# Altium schematic sheet STM32.SchDoc(STM32)
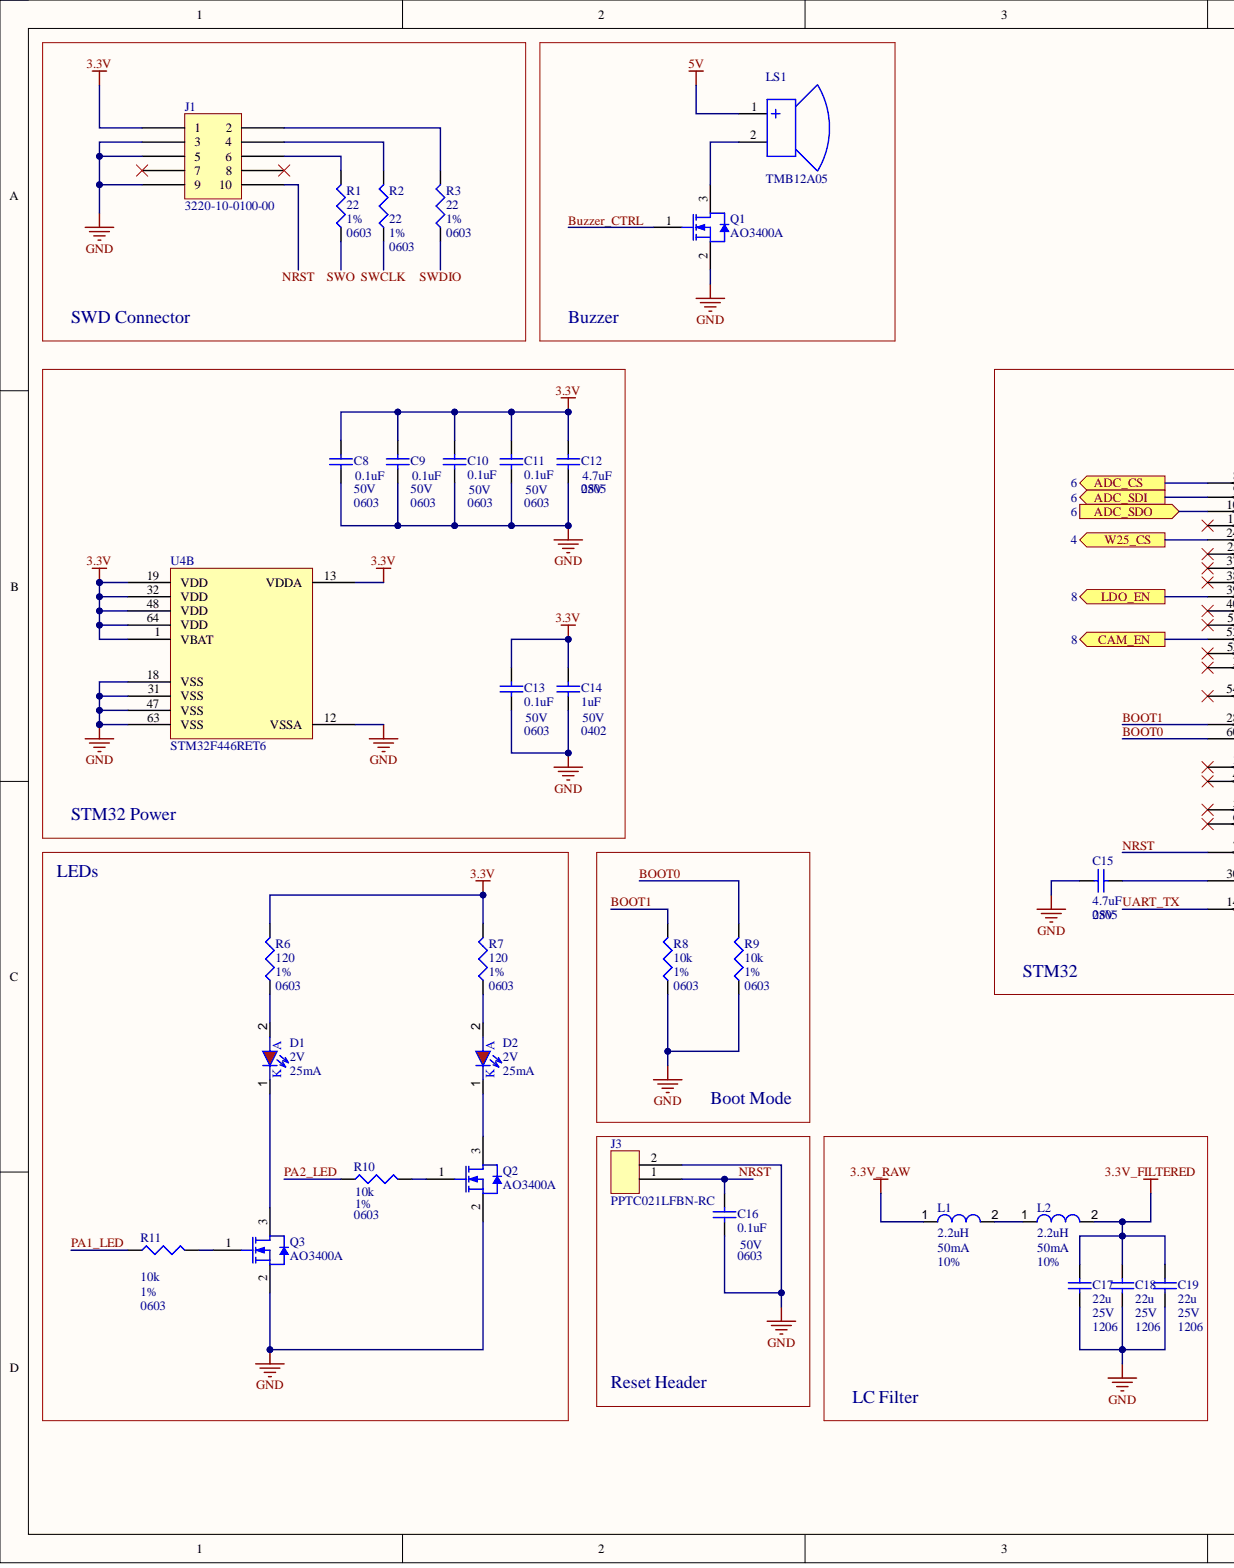
[diagram p0: STM32.SchDoc, left]
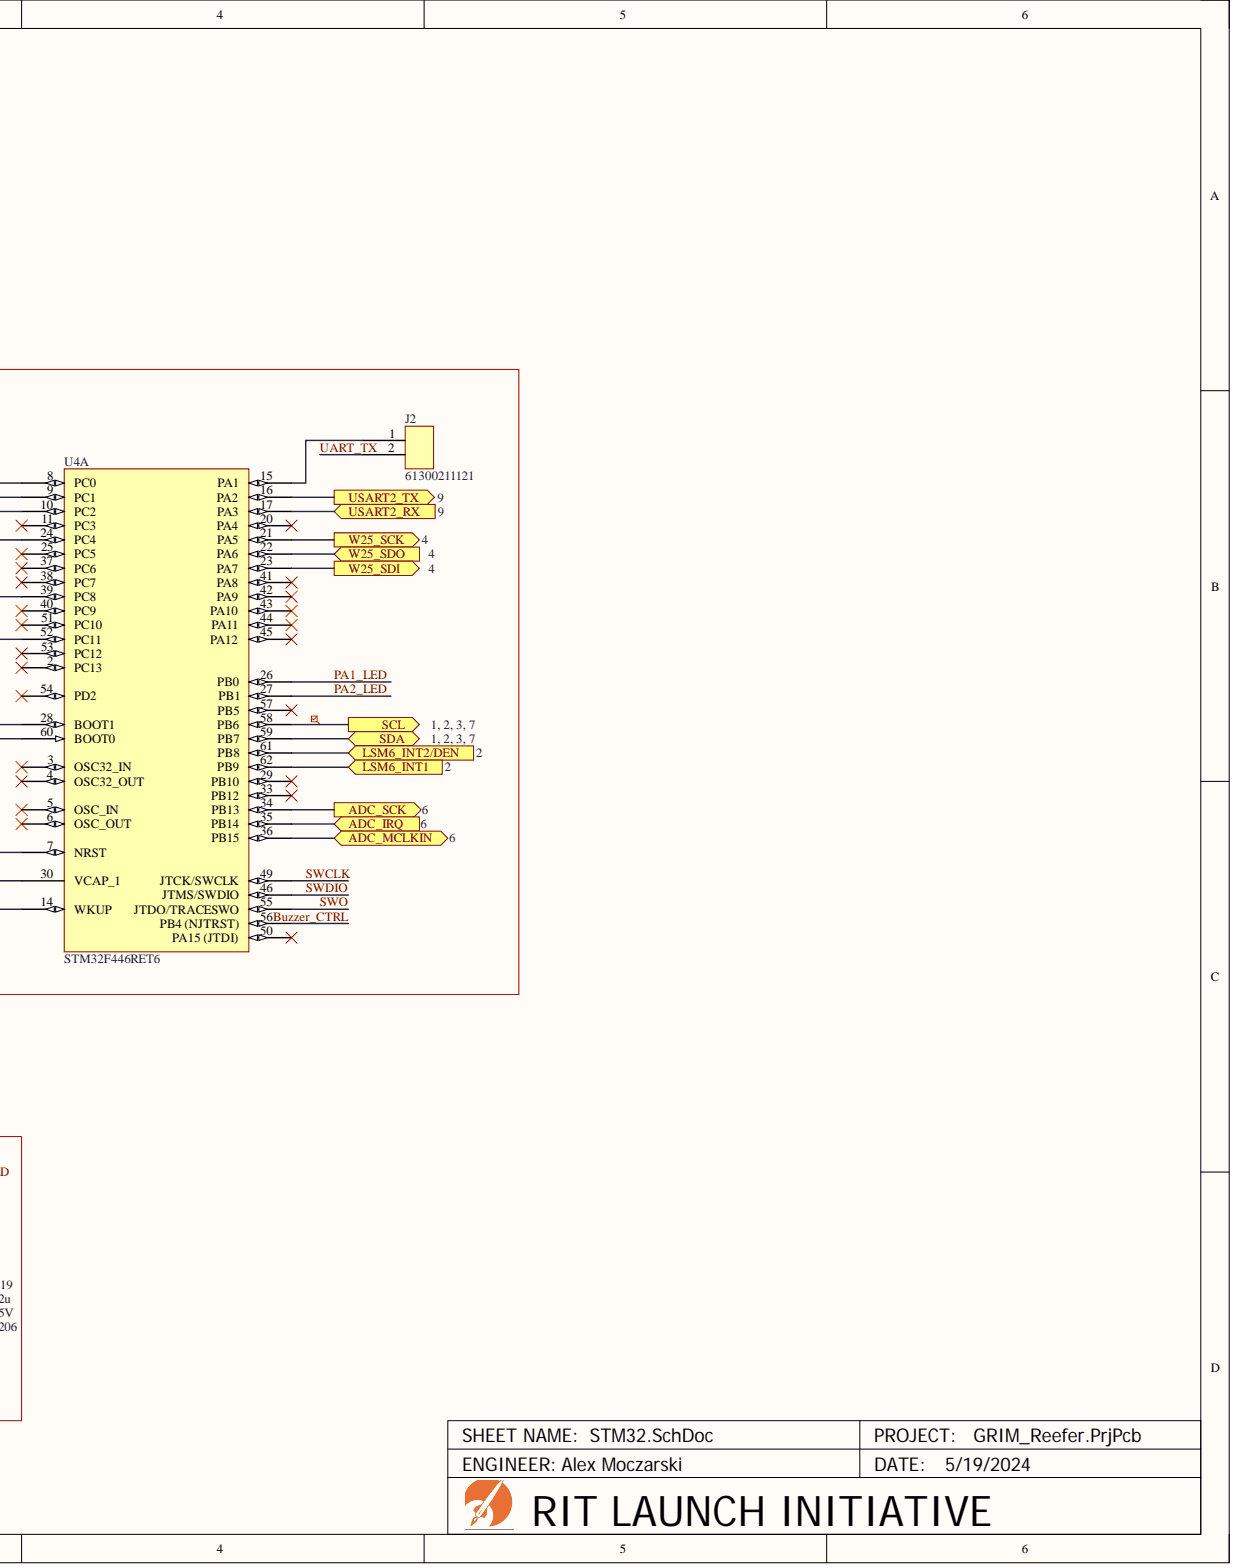
[diagram p1: STM32.SchDoc, right]

## Parts
C8  Capacitance: 0.1uF  Case Code (Imperial): 0603  Voltage: 50V  (hidden comment: CC0603KRX7R9BB104)
C9  Capacitance: 0.1uF  Case Code (Imperial): 0603  Voltage: 50V  (hidden comment: CC0603KRX7R9BB104)
C10  Capacitance: 0.1uF  Case Code (Imperial): 0603  Voltage: 50V  (hidden comment: CC0603KRX7R9BB104)
C11  Capacitance: 0.1uF  Case Code (Imperial): 0603  Voltage: 50V  (hidden comment: CC0603KRX7R9BB104)
C12  Capacitance: 4.7uF  Case/Package: 0805  Voltage: 25V  (hidden comment: CL21A475KAQNNNE)
C13  Capacitance: 0.1uF  Case Code (Imperial): 0603  Voltage: 50V  (hidden comment: CC0603KRX7R9BB104)
C14  Capacitance: 1uF  Case/Package: 0402  Voltage: 50V  (hidden comment: CL05A105KA5NQNC)
C15  Capacitance: 4.7uF  Case/Package: 0805  Voltage: 25V  (hidden comment: CL21A475KAQNNNE)
C16  Capacitance: 0.1uF  Case Code (Imperial): 0603  Voltage: 50V  (hidden comment: CC0603KRX7R9BB104)
C17  Capacitance: 22u  Case Code (Imperial): 1206  Tolerance: 10%  Voltage: 25V  (hidden comment: CL31A226KAHNNNE)
C18  Capacitance: 22u  Case Code (Imperial): 1206  Voltage: 25V  (hidden comment: CL31A226KAHNNNE)
C19  Capacitance: 22u  Case Code (Imperial): 1206  Voltage: 25V  (hidden comment: CL31A226KAHNNNE)
D1  Forward Voltage: 2V  Max Current: 25mA  (hidden comment: NCD0805R1)
D2  Forward Voltage: 2V  Max Current: 25mA  (hidden comment: NCD0805R1)
J1  3220-10-0100-00
J2  61300211121
J3  PPTC021LFBN-RC
L1  Current Rating: 50mA  Inductance: 2.2uH  Tolerance: 10%  (hidden comment: CMI201209U2R2KT)
L2  Inductance: 2.2uH  (hidden comment: CMI201209U2R2KT)
LS1  TMB12A05
Q1  AO3400A
Q2  AO3400A
Q3  AO3400A
R1  Case/Package: 0603  Tolerance: 1%  (hidden comment: 0603WAF220JT5E)
R2  Case/Package: 0603  Tolerance: 1%  (hidden comment: 0603WAF220JT5E)
R3  Case/Package: 0603  Tolerance: 1%  (hidden comment: 0603WAF220JT5E)
R6  Case/Package: 0603  Tolerance: 1%  (hidden comment: 0603WAF1200T5E)
R7  Case/Package: 0603  Tolerance: 1%  (hidden comment: 0603WAF1200T5E)
R8  Case/Package: 0603  Resistance: 10k  Tolerance: 1%  (hidden comment: 0603WAF1002T5E)
R9  Case/Package: 0603  Resistance: 10k  Tolerance: 1%  (hidden comment: 0603WAF1002T5E)
R10  Case/Package: 0603  Resistance: 10k  Tolerance: 1%  (hidden comment: 0603WAF1002T5E)
R11  Resistance: 10k  Tolerance: 1%  (hidden comment: 0603WAF1002T5E)
U4  STM32F446RET6  (parts A,B)

## Ports
ADC_CS, ADC_IRQ, ADC_MCLKIN, ADC_SCK, ADC_SDI, ADC_SDO, CAM_EN, LDO_EN, LSM6_INT1, LSM6_INT2/DEN, SCL, SDA, USART2_RX, USART2_TX, W25_CS, W25_SCK, W25_SDI, W25_SDO

## Nets
3.3V: C8.2 C9.2 C10.2 C11.2 C12.2 C13.2 C14.2 J1.1 R6.2 R7.2 U4.1(VBAT) U4.13(VDDA) U4.19(VDD) U4.32(VDD) U4.48(VDD) U4.64(VDD)
3.3V_FILTERED: C17.2 C18.2 C19.2 L2.2
3.3V_RAW: L1.1
5V: LS1.1
GND: C8.1 C9.1 C10.1 C11.1 C12.1 C13.1 C14.1 C15.1 C16.1 C17.1 C18.1 C19.1 J1.3 J1.5 J1.9 J3.2 Q1.2 Q2.2 Q3.2 R8.1 R9.1 U4.12(VSSA) U4.18(VSS) U4.31(VSS) U4.47(VSS) U4.63(VSS)
ADC_CS: U4.8(PC0) ; port ADC_CS
ADC_IRQ: U4.35(PB14) ; port ADC_IRQ
ADC_MCLKIN: U4.36(PB15) ; port ADC_MCLKIN
ADC_SCK: U4.34(PB13) ; port ADC_SCK
ADC_SDI: U4.9(PC1) ; port ADC_SDI
ADC_SDO: U4.10(PC2) ; port ADC_SDO
BOOT0: R9.2 U4.60(BOOT0)
BOOT1: R8.2 U4.28(BOOT1)
Buzzer_CTRL: Q1.1 U4.56(PB4_(NJTRST))
CAM_EN: U4.52(PC11) ; port CAM_EN
LDO_EN: U4.39(PC8) ; port LDO_EN
LSM6_INT1: U4.62(PB9) ; port LSM6_INT1
LSM6_INT2/DEN: U4.61(PB8) ; port LSM6_INT2/DEN
NRST: C16.2 J1.10 J3.1 U4.7(NRST)
PA1_LED: R11.1 U4.26(PB0)
PA2_LED: R10.1 U4.27(PB1)
SCL: U4.58(PB6) ; port SCL
SDA: U4.59(PB7) ; port SDA
SWCLK: R2.1 U4.49(JTCK/SWCLK)
SWDIO: R3.1 U4.46(JTMS/SWDIO)
SWO: R1.1 U4.55(JTDO/TRACESWO)
UART_TX: J2.2 U4.14(WKUP)
USART2_RX: U4.17(PA3) ; port USART2_RX
USART2_TX: U4.16(PA2) ; port USART2_TX
W25_CS: U4.24(PC4) ; port W25_CS
W25_SCK: U4.21(PA5) ; port W25_SCK
W25_SDI: U4.23(PA7) ; port W25_SDI
W25_SDO: U4.22(PA6) ; port W25_SDO
NetC15_2: C15.2 U4.30(VCAP_1)
NetD1_1: D1.1 Q3.3
NetD1_2: D1.2 R6.1
NetD2_1: D2.1 Q2.3
NetD2_2: D2.2 R7.1
NetJ1_2: J1.2 R3.2
NetJ1_4: J1.4 R2.2
NetJ1_6: J1.6 R1.2
NetJ2_1: J2.1 U4.15(PA1)
NetL1_2: L1.2 L2.1
NetLS1_2: LS1.2 Q1.3
NetQ2_1: Q2.1 R10.2
NetQ3_1: Q3.1 R11.2

Not connected: J1 7 8; U4 2(PC13) 3(OSC32_IN) 4(OSC32_OUT) 5(OSC_IN) 6(OSC_OUT) 11(PC3) 20(PA4) 25(PC5) 29(PB10) 33(PB12) 37(PC6) 38(PC7) 40(PC9) 41(PA8) 42(PA9) 43(PA10) 44(PA11) 45(PA12) 50(PA15_(JTDI)) 51(PC10) 53(PC12) 54(PD2) 57(PB5)

## Library data of the parts
C8-C11 C13 C16 = CMP-001-0242-3: CAP CER 0.1UF 50V X7R 0603; Dielectric Material: Ceramic; Tolerance: 10%; Case Code (Metric): 1608; Case/Package: 0603; Component Kind: Standard; Depth: 0.8mm; Dielectric: X7R; Halogen Free: Halogen Free; Height: 0.8mm; JLCPCB Part #: C14663; Lead Free: Lead Free; Length: 0.063inch; Max Operating Temperature: 125°C; Min Operating Temperature: -55°C; Mount: Surface Mount; Number of Pins: 2; Package Quantity: 1; Packaging: Tape and Reel; Radiation Hardening: No; REACH SVHC: No SVHC; RoHS Compliant: Yes; Series: CC; Termination: SMD/SMT; Thickness: 0.035inch; Voltage Rating: 50V; Width: 0.031inch; Library Name: RIT Launch Initiative
C12 C15 = CMP-001-0285-2: 25V 4.7uF X5R ±10% 0805 Multilayer Ceramic Capacitors MLCC - SMD/SMT ROHS; Value: 4.7µF; Capacitor Type: Ceramic; Component Kind: Standard; JLCPCB Part #: C1779; Max Operating Temperature: 85°C; Min Operating Temperature: -55°C; Mounting Technology: Surface Mount; Package: 0805; Pins: 2; RoHS Compliant: Yes; Library Name: RIT Launch Initiative
C14 = CMP-001-0296-1: CAP CER 1uF 50V X5R 0402; Material: Ceramic; Tolerance: 10%; Component Kind: Standard; Dielectric: X5R; JLCPCB Part #: C52923; Max Operating Temperature: 85°C; Min Operating Temperature: -55°C; Mounting Technology: Surface Mount; Series: CL; Library Name: RIT Launch Initiative
C17 = CMP-001-0331-1: CAP CER 22UF 25V X5R 1206; Material: Ceramic; Case Code (Metric): 3216; Case/Package: 1206; Component Kind: Standard; Construction: Flat; Contact Plating: Tin; Depth: 1.6mm; Dielectric: X5R; Height: 1.6mm; JLCPCB Part #: C12891; Length: 3.2mm; Max Operating Temperature: 85°C; Min Operating Temperature: -55°C; Mounting Technology: Surface Mount; Package Quantity: 1; Packaging: Tape and Reel; Radiation Hardening: No; RoHS Compliant: Yes; Series: CL; Thickness: 0.071inch; Width: 0.063inch; Library Name: RIT Launch Initiative
C18 C19 = CMP-001-0331-1: CAP CER 22UF 25V X5R 1206; Material: Ceramic; Tolerance: 10%; Case Code (Metric): 3216; Case/Package: 1206; Component Kind: Standard; Construction: Flat; Contact Plating: Tin; Depth: 1.6mm; Dielectric: X5R; Height: 1.6mm; JLCPCB Part #: C12891; Length: 3.2mm; Max Operating Temperature: 85°C; Min Operating Temperature: -55°C; Mounting Technology: Surface Mount; Package Quantity: 1; Packaging: Tape and Reel; Radiation Hardening: No; RoHS Compliant: Yes; Series: CL; Thickness: 0.071inch; Width: 0.063inch; Library Name: RIT Launch Initiative
D1 D2 = CMP-001-0246-3: LED RED CLEAR 0805 SMD; Component Kind: Standard; JLCPCB Part #: C84256; Library Name: RIT Launch Initiative
J1 = CMP-001-0340-1: CONN HEADER VERT 10POS 1.27MM; Number of Positions: 10; Pitch: 0.05inch; Component Kind: Standard; Connector Type: Header; Contact Finish - Mating: GOLD; Contact Material: Brass; Contact Plating: Gold; Contact Shape: Square; Contact Type: Male Pin; Fastening Type: Pull; Flammability Rating: UL94 V-0; Insulation Material: Nylon; Max Operating Temperature: 105°C; Min Operating Temperature: -40°C; Mounting Technology: Through Hole; Number of Rows: 2; Package Quantity: 3200; Packaging: Tray; RoHS Compliant: Yes; Row Spacing: 0.05inch; Series: -; Termination: Solder; Library Name: RIT Launch Initiative
J2 = CMP-001-0080-1: CONN HEADER VERT 2POS 2.54MM; Component Kind: Standard; Library Name: RIT Launch Initiative
J3 = CMP-001-0336-1: CONN HDR 2POS 0.1 TIN PCB; Connector Type: Header; Number of Positions: 2; Pitch: 2.54mm; Voltage Rating: 250V; Color: Black; Component Kind: Standard; Contact Finish - Mating: TIN; Contact Material: Bronze; Contact Plating: Tin; Contact Shape: Square; Contact Type: Female Socket; Fastening Type: Pull; Flammability Rating: UL94 V-0; Height: 8.5mm; Housing Material: Plastic; Insulation Color: Black; Insulation Material: Polyester; Lead Free: Lead Free; Length: 5.58mm; Max Operating Temperature: 105°C; Min Operating Temperature: -40°C; Mounting Technology: Through Hole; Number of Rows: 1; Package Quantity: 1000; Packaging: Tray; RoHS Compliant: Yes; Series: -; Termination: Solder; Width: 2.5mm; Library Name: RIT Launch Initiative
L1 = CMP-006-00021-2: Inductor 2.2uH 50mA 0805; Case Code (Imperial): 0805; Case Code (Metric): 2012; Case/Package: SMD/SMT; Component Kind: Standard; JLCPCB Part #: C1043; Max DC Current: 50mA; Library Name: RIT Launch Initiative
L2 = CMP-006-00021-2: Inductor 2.2uH 50mA 0805; Tolerance: 10%; Case Code (Imperial): 0805; Case Code (Metric): 2012; Case/Package: SMD/SMT; Component Kind: Standard; Current Rating: 50mA; JLCPCB Part #: C1043; Max DC Current: 50mA; Library Name: RIT Launch Initiative
LS1 = CMP-001-0337-1: BUZZER MAGNETIC 5V 12MM TH; Component Kind: Standard; JLCPCB Part #: C781860; Library Name: RIT Launch Initiative
Q1-Q3 = CMP-001-0127-4: MOSFET N-CH 30V 5.7A SOT23-3L; Gate to Source Voltage (Vgs): 12V; Input Capacitance: 630pF; Max Power Dissipation: 1.4W; Number of Elements: 1; Rds On Max: 26.5mR; Case/Package: TO-236-3; Component Kind: Standard; Continuous Drain Current (ID): 5.7A; Drain to Source Voltage (Vdss): 30V; JLCPCB Part #: C20917; Lead Free: Lead Free; Max Operating Temperature: 150°C; Min Operating Temperature: -55°C; Mounting Technology: Surface Mount; Packaging: Cut Tape; Pins: 3; Power Dissipation: 1.4W; Radiation Hardening: No; RoHS Compliant: Yes; Series: -; Library Name: RIT Launch Initiative
R1-R3 = CMP-001-0306-1: RES 22 OHM 1% 1/10W 0603; Resistance: 22; Component Kind: Standard; JLCPCB Part #: C23345; RoHS Compliant: Yes; Library Name: RIT Launch Initiative
R6 R7 = CMP-001-0272-1: RES 120 OHM 1% 1/10W 0603; Resistance: 120; Component Kind: Standard; JLCPCB Part #: C22787; Library Name: RIT Launch Initiative
R8-R11 = CMP-001-0268-1: RES 10K OHM 1% 1/10W 0603; Component Kind: Standard; JLCPCB Part #: C25804; RoHS Compliant: Yes; Library Name: RIT Launch Initiative
  R11: Case/Package: 0603
U4 = CMP-001-0264-3: IC MCU 32BIT 512KB FLASH 64LQFP; Core Architecture: ARM; Data Bus Width: 32b; Frequency: 180MHz; Interface: I2C,SPI,USB,CAN,IrDA,SD,UART,USART,LIN,EBI/EMI; Max Power Dissipation: 345mW; Max Supply Voltage: 3.6V; Min Supply Voltage: 1.7V; Number of I/Os: 50; Number of Timers/Counters: 14; Oscillator Type: Internal; Peripherals: Brown-out Detect/Reset,POR,PWM,WDT,DMA,LVD; RAM Size: 128KB; Watchdog Timer: Yes; Case/Package: LQFP; Component Kind: Standard; JLCPCB Part #: C69336; Lead Free: Lead Free; Max Frequency: 180MHz; Max Operating Temperature: 85°C; Memory Size: 512KB; Memory Type: FLASH; Min Operating Temperature: -40°C; Mount: Surface Mount; Number of Pins: 64; Number of Programmable I/O: 50; Packaging: Tray; REACH SVHC: No SVHC; RoHS Compliant: Yes; Series: STM32 F4; Library Name: RIT Launch Initiative
